# Altium schematic sheet 09_Misc.SchDoc(09_Misc)
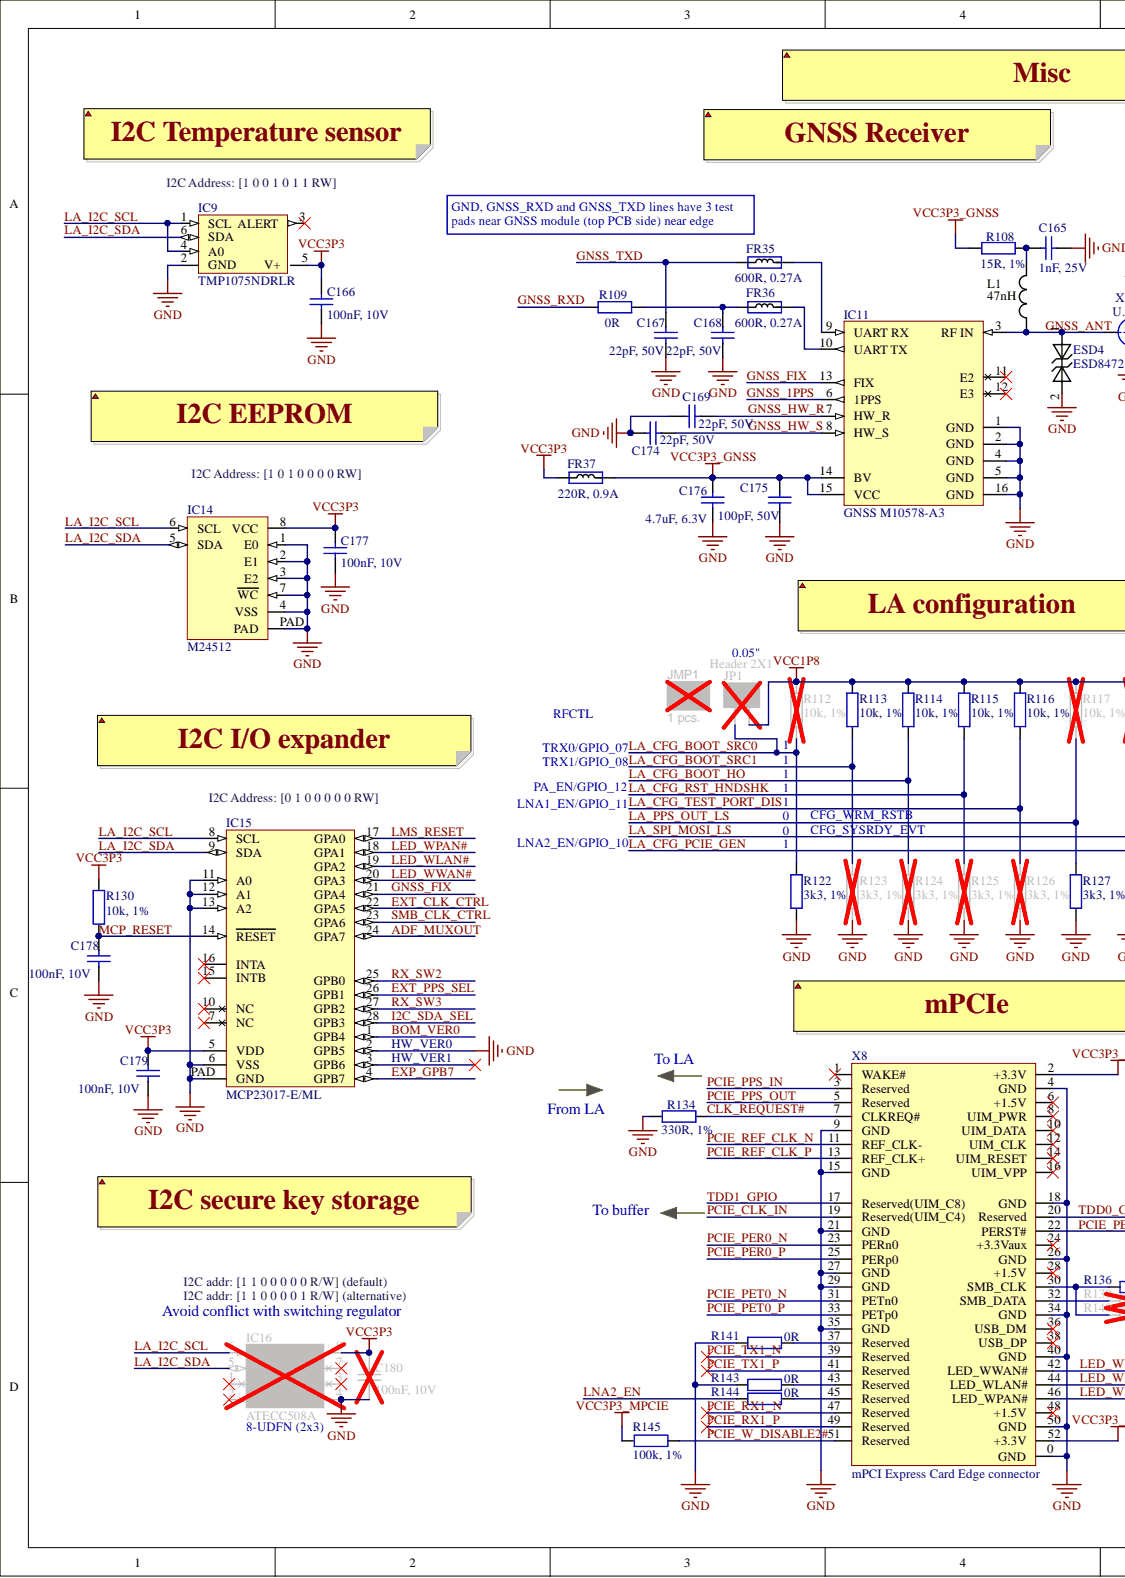
[diagram p0: 09_Misc.SchDoc, left]
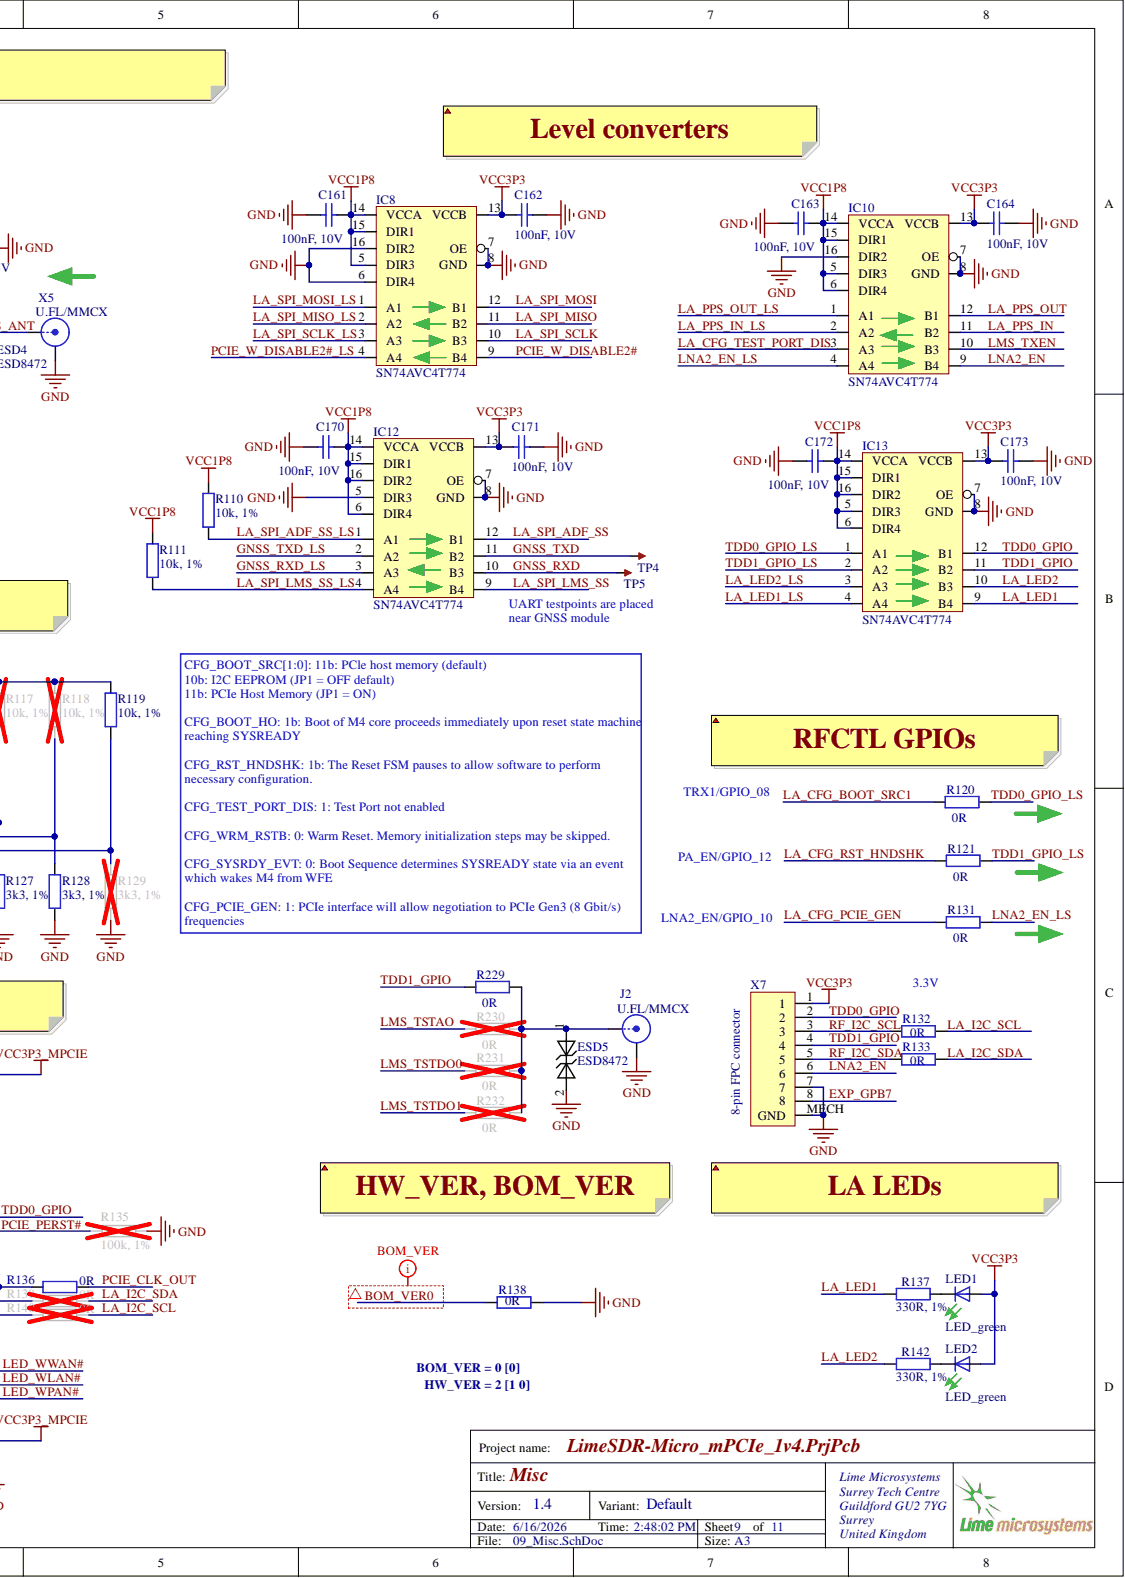
[diagram p1: 09_Misc.SchDoc, right]

## Parts
C161  100nF, 10V  Voltage Rating (DC): 10V  [CAP0201]
C162  100nF, 10V  Voltage Rating (DC): 10V  [CAP0201]
C163  100nF, 10V  Voltage Rating (DC): 10V  [CAP0201]
C164  100nF, 10V  Voltage Rating (DC): 10V  [CAP0201]
C165  1nF, 25V  Voltage - Rated: 25V  [CAP0201]
C166  100nF, 10V  Voltage Rating (DC): 10V  [CAP0201]
C167  22pF, 50V  Voltage Rating (DC): 50V  [CAP0201]
C168  22pF, 50V  Voltage Rating (DC): 50V  [CAP0201]
C169  22pF, 50V  Voltage Rating (DC): 50V  [CAP0201]
C170  100nF, 10V  Voltage Rating (DC): 10V  [CAP0201]
C171  100nF, 10V  Voltage Rating (DC): 10V  [CAP0201]
C172  100nF, 10V  Voltage Rating (DC): 10V  [CAP0201]
C173  100nF, 10V  Voltage Rating (DC): 10V  [CAP0201]
C174  22pF, 50V  [CAP0201]
C175  100pF, 50V  Voltage Rating (DC): 50V  [CAP0201]
C176  4.7uF, 6.3V  Voltage - Rated: 6.3V  [CAP0402]
C177  100nF, 10V  Voltage Rating (DC): 10V  [CAP0201]
C178  100nF, 10V  Voltage Rating (DC): 10V  [CAP0201]
C179  100nF, 10V  Voltage Rating (DC): 10V  [CAP0201]
C180  100nF, 10V  Voltage Rating (DC): 10V  [CAP0201]
ESD4  ESD8472  [ESD8472_X3DFN2]
ESD5  ESD8472  [ESD8472_X3DFN2]
FR35  600R, 0.27A  [RES0201]
FR36  600R, 0.27A  [RES0201]
FR37  220R, 0.9A  [RES0402]
IC8  SN74AVC4T774  [SN74AVC4T774_v1]
IC9  TMP1075NDRLR  [TMP1075NDRLR_temperature_sensor_SOT563]
IC10  SN74AVC4T774  [SN74AVC4T774_v1]
IC11  GNSS M10578-A3  Manufacturer Part Number 1: M10578-A3  Data Interface: UART  [M10578-A3_v2]
IC12  SN74AVC4T774  [SN74AVC4T774_v1]
IC13  SN74AVC4T774  [SN74AVC4T774_v1]
IC14  M24512  [M24128-DFMC6TG]
IC15  MCP23017-E/ML  [MCP23017-E/ML_QFN28]
IC16  ATECC508A  [ATECC508A_UDFN8_v2]
J2  U.FL/MMCX  [MMCX_UFL_conn_u_v2]
JMP1  1 pcs.  [MECH_JUMPER_HALF_PITCH]
JP1  Header 2X1  [HEADER_2x1_TH_HALF_PITCH]
L1  47nH  [RES0402]
LED1  LED_green  [LED0201]
LED2  LED_green  [LED0201]
R108  15R, 1%  [RES0201]
R109  0R  [RES0201]
R110  10k, 1%  Tolerance: 1%  [RES0201]
R111  10k, 1%  Tolerance: 1%  [RES0201]
R112  10k, 1%  [RES0201]
R113  10k, 1%  Tolerance: 1%  [RES0201]
R114  10k, 1%  Tolerance: 1%  [RES0201]
R115  10k, 1%  Tolerance: 1%  [RES0201]
R116  10k, 1%  Tolerance: 1%  [RES0201]
R117  10k, 1%  Tolerance: 1%  [RES0201]
R118  10k, 1%  Tolerance: 1%  [RES0201]
R119  10k, 1%  Tolerance: 1%  [RES0201]
R120  0R  [RES0201]
R121  0R  [RES0201]
R122  3k3, 1%  [RES0201]
R123  3k3, 1%  Tolerance: 1%  [RES0201]
R124  3k3, 1%  Tolerance: 1%  [RES0201]
R125  3k3, 1%  Tolerance: 1%  [RES0201]
R126  3k3, 1%  Tolerance: 1%  [RES0201]
R127  3k3, 1%  Tolerance: 1%  [RES0201]
R128  3k3, 1%  Tolerance: 1%  [RES0201]
R129  3k3, 1%  Tolerance: 1%  [RES0201]
R130  10k, 1%  Tolerance: 1%  [RES0201]
R131  0R  [RES0201]
R132  0R  [RES0201]
R133  0R  [RES0201]
R134  330R, 1%  Tolerance: 1%  [RES0201]
R135  100k, 1%  Tolerance: 1%  [RES0201]
R136  0R  [RES0201]
R137  330R, 1%  Tolerance: 1%  [RES0201]
R138  0R  [RES0201]
R139  0R  [RES0201]
R140  0R  [RES0201]
R141  0R  [RES0201]
R142  330R, 1%  Tolerance: 1%  [RES0201]
R143  0R  [RES0201]
R144  0R  [RES0201]
R145  100k, 1%  Tolerance: 1%  [RES0201]
R229  0R  [RES0201]
R230  0R  [RES0201]
R231  0R  [RES0201]
R232  0R  [RES0201]
X5  U.FL/MMCX  [MMCX_UFL_conn_u_v2]
X7  8-pin FPC connector  [503480-0800_8PIN_FPC_CONN]
X8  mPCI Express Card Edge connector  [PCIe_MiniCard]  (no BOM)

## Nets
GND: C161.1 C162.2 C163.1 C164.2 C165.2 C166.2 C167.2 C168.2 C169.2 C170.1 C171.2 C172.1 C173.2 C174.2 C175.1 C176.1 C177.2 C178.2 C179.2 C180.2 ESD4.2 ESD5.2 IC8.6(DIR4) IC8.7(OE) IC8.8(GND) IC8.16(DIR2) IC9.2(GND) IC10.7(OE) IC10.8(GND) IC10.16(DIR2) IC11.1(GND) IC11.2(GND) IC11.4(GND) IC11.5(GND) IC11.16(GND) IC12.5(DIR3) IC12.7(OE) IC12.8(GND) IC13.7(OE) IC13.8(GND) IC14.1(E0) IC14.2(E1) IC14.3(E2) IC14.4(VSS) IC14.7(WC) IC14.PAD IC15.2(GPB5) IC15.6(VSS) IC15.11(A0) IC15.12(A1) IC15.13(A2) IC15.PAD(GND) IC16.4(GND) J2.2 R122.2 R123.2 R124.2 R125.2 R126.2 R127.2 R128.2 R129.2 R134.2 R135.1 R138.2 R141.1 R143.1 X5.2 X7.7 X7.MECH(GND) X8.0(GND) X8.4(GND) X8.9(GND) X8.15(GND) X8.18(GND) X8.21(GND) X8.26(GND) X8.27(GND) X8.29(GND) X8.34(GND) X8.35(GND) X8.40(GND) X8.50(GND)
VCC1P8: C161.2 C163.2 C170.2 C172.2 IC8.5(DIR3) IC8.14(VCCA) IC8.15(DIR1) IC10.5(DIR3) IC10.6(DIR4) IC10.14(VCCA) IC10.15(DIR1) IC12.6(DIR4) IC12.14(VCCA) IC12.15(DIR1) IC12.16(DIR2) IC13.5(DIR3) IC13.6(DIR4) IC13.14(VCCA) IC13.15(DIR1) IC13.16(DIR2) JP1.2 R110.1 R111.1 R112.1 R113.1 R114.1 R115.1 R116.1 R117.1 R118.1 R119.1
VCC3P3: C162.1 C164.1 C166.1 C171.1 C173.1 C177.1 C179.1 C180.1 FR37.1 IC8.13(VCCB) IC9.5(V+) IC10.13(VCCB) IC12.13(VCCB) IC13.13(VCCB) IC14.8(VCC) IC15.5(VDD) IC16.8(VCC) LED1.A LED2.A R130.1 X7.1
VCC3P3_GNSS: C175.2 C176.2 FR37.2 IC11.14(BV) IC11.15(VCC) R108.2
VCC3P3_MPCIE: R145.2 X8.2(+3.3V) X8.52(+3.3V)
ADF_MUXOUT: IC15.24(GPA7)
BOM_VER0: IC15.1(GPB4) R138.1
CLK_REQUEST#: R134.1 X8.7(CLKREQ#)
EXP_GPB7: IC15.4(GPB7) X7.8
EXT_CLK_CTRL: IC15.22(GPA5)
EXT_PPS_SEL: IC15.26(GPB1)
GNSS_1PPS: IC11.6(1PPS)
GNSS_ANT: ESD4.1 IC11.3(RF_IN) L1.1 X5.1
GNSS_FIX: IC11.13(FIX) IC15.21(GPA4)
GNSS_HW_R: C169.1 IC11.7(HW_R)
GNSS_HW_S: C174.1 IC11.8(HW_S)
GNSS_RXD: IC12.10(B3) R109.1
GNSS_RXD_LS: IC12.3(A3)
GNSS_TXD: C167.1 FR35.1 IC12.11(B2)
GNSS_TXD_LS: IC12.2(A2)
I2C_SDA_SEL: IC15.28(GPB3)
LA_CFG_BOOT_HO: R114.2 R124.1
LA_CFG_BOOT_SRC0: JP1.1 R112.2 R122.1
LA_CFG_BOOT_SRC1: R113.2 R120.1 R123.1
LA_CFG_PCIE_GEN: R119.2 R129.1 R131.1
LA_CFG_RST_HNDSHK: R115.2 R121.1 R125.1
LA_CFG_TEST_PORT_DIS: IC10.3(A3) R116.2 R126.1
LA_I2C_SCL: IC9.1(SCL) IC9.4(A0) IC14.6(SCL) IC15.8(SCL) IC16.6(SCL) R132.2 R140.2
LA_I2C_SDA: IC9.6(SDA) IC14.5(SDA) IC15.9(SDA) IC16.5(SDA) R133.2 R139.2
LA_LED1: IC13.9(B4) R137.2
LA_LED1_LS: IC13.4(A4)
LA_LED2: IC13.10(B3) R142.2
LA_LED2_LS: IC13.3(A3)
LA_PPS_IN: IC10.11(B2)
LA_PPS_IN_LS: IC10.2(A2)
LA_PPS_OUT: IC10.12(B1)
LA_PPS_OUT_LS: IC10.1(A1) R117.2 R127.1
LA_SPI_ADF_SS: IC12.12(B1)
LA_SPI_ADF_SS_LS: IC12.1(A1) R110.2
LA_SPI_LMS_SS: IC12.9(B4)
LA_SPI_LMS_SS_LS: IC12.4(A4) R111.2
LA_SPI_MISO: IC8.11(B2)
LA_SPI_MISO_LS: IC8.2(A2)
LA_SPI_MOSI: IC8.12(B1)
LA_SPI_MOSI_LS: IC8.1(A1) R118.2 R128.1
LA_SPI_SCLK: IC8.10(B3)
LA_SPI_SCLK_LS: IC8.3(A3)
LED_WLAN#: IC15.19(GPA2) X8.44(LED_WLAN#)
LED_WPAN#: IC15.18(GPA1) X8.46(LED_WPAN#)
LED_WWAN#: IC15.20(GPA3) X8.42(LED_WWAN#)
LMS_RESET: IC15.17(GPA0)
LMS_TSTAO: R230.1
LMS_TSTDO0: R231.1
LMS_TSTDO1: R232.1
LMS_TXEN: IC10.10(B3)
LNA2_EN: IC10.9(B4) R144.1 X7.6
LNA2_EN_LS: IC10.4(A4) R131.2
MCP_RESET: C178.1 IC15.14(RESET) R130.2
PCIE_CLK_IN: X8.19(Reserved(UIM_C4))
PCIE_CLK_OUT: R136.2
PCIE_PER0_N: X8.23(PERn0)
PCIE_PER0_P: X8.25(PERp0)
PCIE_PERST#: R135.2 X8.22(PERST#)
PCIE_PET0_N: X8.31(PETn0)
PCIE_PET0_P: X8.33(PETp0)
PCIE_PPS_IN: X8.3(Reserved)
PCIE_PPS_OUT: X8.5(Reserved)
PCIE_REF_CLK_N: X8.11(REF_CLK-)
PCIE_REF_CLK_P: X8.13(REF_CLK+)
PCIE_RX1_N: X8.47(Reserved)
PCIE_RX1_P: X8.49(Reserved)
PCIE_TX1_N: X8.39(Reserved)
PCIE_TX1_P: X8.41(Reserved)
PCIE_W_DISABLE2#: IC8.9(B4) R145.1 X8.51(Reserved)
PCIE_W_DISABLE2#_LS: IC8.4(A4)
RF_I2C_SCL: R132.1 X7.3
RF_I2C_SDA: R133.1 X7.5
RX_SW2: IC15.25(GPB0)
RX_SW3: IC15.27(GPB2)
SMB_CLK_CTRL: IC15.23(GPA6)
TDD0_GPIO: IC13.12(B1) X7.2 X8.20(Reserved)
TDD0_GPIO_LS: IC13.1(A1) R120.2
TDD1_GPIO: IC13.11(B2) R229.1 X7.4 X8.17(Reserved(UIM_C8))
TDD1_GPIO_LS: IC13.2(A2) R121.2
NetC165_1: C165.1 L1.2 R108.1
NetC168_1: C168.1 FR36.1 R109.2
NetESD5_1: ESD5.1 J2.1 R229.2 R230.2 R231.2 R232.2
NetFR35_2: FR35.2 IC11.9(UART_RX)
NetFR36_2: FR36.2 IC11.10(UART_TX)
NetLED1_K: LED1.K R137.1
NetLED2_K: LED2.K R142.1
NetR136_1: R136.1 R140.1 X8.30(SMB_CLK)
NetR139_1: R139.1 X8.32(SMB_DATA)
NetR141_2: R141.2 X8.37(Reserved)
NetR143_2: R143.2 X8.43(Reserved)
NetR144_2: R144.2 X8.45(Reserved)
N00444:

Not connected: IC9 3(ALERT); IC11 11(E2) 12(E3); IC15 3(GPB6) 7(NC) 10(NC) 15(INTB) 16(INTA); IC16 1(NC) 2(NC) 3(NC) 7(NC); X8 1(WAKE#) 6(+1.5V) 8(UIM_PWR) 10(UIM_DATA) 12(UIM_CLK) 14(UIM_RESET) 16(UIM_VPP) 24(+3.3Vaux) 28(+1.5V) 36(USB_DM) 38(USB_DP) 48(+1.5V)

## Library data of the parts
C161-C164 C166 C170-C173 C177-C180 = Cap_0402: Part Description: 0.1 µF ±20% 10V Ceramic Capacitor X5R 0201 (0603 Metric); Manufacturer Part Number 1: CL03A104MP3NNNC; Manufacturer: Samsung; Tolerance: 20%; Width: 0.012inch; Voltage Rating: 10V; Value: 100nF, 10V; Thickness: 0.013inch; Supplier Part Number 1: 1276-1321-1-ND; Supplier 1: Digi-Key; Stock 1: 3817176; Series: CL; RoHS Compliant: Yes; RoHS: Yes; Pricing 1: 1=0,10000, 10=0,03000, 50=0,01640, 100=0,01330, 500=0,00950, 1000=0,00747, 2500=0,00679, 5000=0,00625 (USD); Packaging: Tape and Reel; Package Quantity: 1; Mount: Surface Mount; Min Operating Temperature: -55°C; Max Operating Temperature: 85°C; Length: 0.024inch; ComponentLink5URL: https://datasheet.ciiva.com/25788/cl03a104mp3nnnc-25788272.pdf?src-supplier=Digi-Key; ComponentLink5Description: https://datasheet.ciiva.com/25788/cl03a104mp3nnnc-25788272.pdf?src-supplier=Digi-Key; Component Kind: Standard; Case Code (Metric): 0603; Case Code (Imperial): 0201; Case/Package: 0201; Capacitance: 100nF; Library Name: LimeMicroAltiumLib_schLib.SchLib
C165 = Cap_0402: Part Description: 1000pF ±10% 25V X7R Ceramic Capacitor -55°C ~ 125°C Surface Mount, MLCC 0201 (0603 Metric) 0.024" L x 0.012" W (0.60mm x 0.30mm); Manufacturer Part Number 1: C0603X7R1E102K030BA; Manufacturer: TDK Corporation; Tolerance: ±10%; Temperature Coefficient: X7R; Capacitance: 1000pF; Value: 1nF, 25V; Thickness (Max): 0.013" (0.33mm); Supplier Part Number 1: 445-7275-1-ND; Supplier 1: Digi-Key; Size / Dimension: 0.024" L x 0.012" W (0.60mm x 0.30mm); RoHS: RoHS Compliant; Ratings: -; Packaging: Cut Tape (CT); Package / Case: 0201 (0603 Metric); Operating Temperature: -55°C ~ 125°C; Mounting Type: Surface Mount, MLCC; Lead Style: -; Lead Spacing: -; Height - Seated (Max): -; Features: -; Component Kind: Standard; Category: Capacitors; Applications: General Purpose; Library Name: LimeMicroAltiumLib_schLib.SchLib
C167-C169 C174 = Cap_0402: Part Description: 22 pF ±5% 50V Ceramic Capacitor C0G, NP0 0201 (0603 Metric); Manufacturer Part Number 1: GRM0335C1H220JA01D; Manufacturer: Murata; Tolerance: 5%; Dielectric: C0G; Width: 0.012inch; Voltage Rating: 50V; Voltage: 50V; Value: 22pF, 50V; Thickness: 0.013inch; Termination: SMD/SMT; Supplier Part Number 1: 490-6123-1-ND; Supplier 1: Digi-Key; Stock 1: 2966748; Series: GRM; RoHS Compliant: Yes; RoHS: Yes; Pricing 1: 1=0,10000, 10=0,01300, 50=0,00720, 100=0,00590, 500=0,00424, 1000=0,00333, 2500=0,00303, 5000=0,00279 (USD); Packaging: Tape and Reel; Package Quantity: 15000; Mount: Surface Mount; Min Operating Temperature: -55°C; Max Operating Temperature: 125°C; Length: 0.6mm; Lead Free: Lead Free; Height: 0.3mm; Depth: 0.3mm; ComponentLink3URL: https://datasheet.ciiva.com/6271/partnumbering-e-01-6271149.pdf?src-supplier=Digi-Key; ComponentLink3Description: https://datasheet.ciiva.com/6271/partnumbering-e-01-6271149.pdf?src-supplier=Digi-Key; ComponentLink2URL: https://datasheet.ciiva.com/6271/partnumbering-e-01-6271143.pdf?src-supplier=Digi-Key; ComponentLink2Description: https://datasheet.ciiva.com/6271/partnumbering-e-01-6271143.pdf?src-supplier=Digi-Key; ComponentLink1URL: https://datasheet.ciiva.com/6271/partnumbering-e-01-6271146.pdf?src-supplier=Digi-Key; ComponentLink1Description: https://datasheet.ciiva.com/6271/partnumbering-e-01-6271146.pdf?src-supplier=Digi-Key; Component Kind: Standard; Case Code (Metric): 0603; Case Code (Imperial): 0201; Case/Package: 0201; Capacitance: 22pF; Library Name: LimeMicroAltiumLib_schLib.SchLib
  C174: Voltage Rating (DC): 50V
C175 = Cap_0402: Part Description: 100 pF ±5% 50V Ceramic Capacitor C0G, NP0 0201 (0603 Metric); Manufacturer Part Number 1: C0603C0G1H101J030BA; Manufacturer: TDK; Tolerance: 5%; Insulation Resistance: 10GR; Dielectric Material: Ceramic; Dielectric: C0G; Width: 0.012inch; Weight: 6E-06oz; Voltage Rating: 50V; Voltage: 50V; Value: 100pF, 50V; Thickness: 0.013inch; Termination: SMD/SMT; Supplier Part Number 1: 445-1786-1-ND; Supplier 1: Digi-Key; Stock 1: 367528; Series: C; RoHS Compliant: Yes; RoHS: Yes; Reach SVHC Compliant: Yes; Pricing 1: 1=0,10000, 10=0,06000, 50=0,03280, 100=0,02670, 500=0,01908, 1000=0,01500, 2500=0,01364, 5000=0,01254 (USD); Packaging: Tape and Reel; Package Quantity: 1; Number of Pins: 2; Mount: Surface Mount; Min Operating Temperature: -55°C; Max Operating Temperature: 125°C; Length: 0.6mm; Lead Free: Lead Free; Height: 0.3mm; Depth: 0.3mm; Construction: Flat; ComponentLink3URL: https://datasheet.ciiva.com/6250/tdkmlcccaprange-6250351.pdf?src-supplier=Digi-Key; ComponentLink3Description: https://datasheet.ciiva.com/6250/tdkmlcccaprange-6250351.pdf?src-supplier=Digi-Key; ComponentLink2URL: https://datasheet.ciiva.com/16205/mlcc-commercial-general-en-16205590.pdf?src-supplier=Future+Electronics; ComponentLink2Description: https://datasheet.ciiva.com/16205/mlcc-commercial-general-en-16205590.pdf?src-supplier=Future+Electronics; ComponentLink1URL: https://datasheet.ciiva.com/24217/c0603c0g1h101j030ba-264106-24217425.pdf?src-supplier=Mouser; ComponentLink1Description: https://datasheet.ciiva.com/24217/c0603c0g1h101j030ba-264106-24217425.pdf?src-supplier=Mouser; Component Kind: Standard; Case Code (Metric): 0603; Case Code (Imperial): 0201; Case/Package: 0201; Capacitance: 100pF; Library Name: LimeMicroAltiumLib_schLib.SchLib
C176 = Cap_0402: Part Description: 4.7µF ±20% 6.3V X5R Ceramic Capacitor -55°C ~ 85°C Surface Mount, MLCC 0402 (1005 Metric) 0.039" L x 0.020" W (1.00mm x 0.50mm); Manufacturer Part Number 1: GRM155R60J475ME87D; Manufacturer: Murata Electronics North America; Tolerance: ±20%; Temperature Coefficient: X5R; Capacitance: 4.7µF; Value: 4.7uF, 6.3V; Thickness (Max): 0.022" (0.55mm); Supplier Part Number 1: 490-5408-1-ND; Supplier 1: Digi-Key; Size / Dimension: 0.039" L x 0.020" W (1.00mm x 0.50mm); RoHS: RoHS Compliant; Ratings: -; Packaging: Cut Tape (CT); Package / Case: 0402 (1005 Metric); Operating Temperature: -55°C ~ 85°C; Mounting Type: Surface Mount, MLCC; Lead Style: -; Lead Spacing: -; Height - Seated (Max): -; Features: Derating Recommended; Component Kind: Standard; Category: Capacitors; Applications: General Purpose; Library Name: LimeMicroAltiumLib_schLib.SchLib
ESD4 ESD5 = ESD8472: Part Description: TVS DIODE 5.3VWM 14VC X3DFN2; Manufacturer Part Number 1: ESD8472MUT5G; Manufacturer: ON Semiconductor; Voltage - Reverse Standoff (Typ): 5.3V (Max); Voltage - Clamping (Max) @ Ipp: 14V; Voltage - Breakdown (Min): 7V; Power Line Protection: No; Current - Peak Pulse (10/1000µs): 3A (8/20µs); Capacitance @ Frequency: 0.2pF @ 1MHz; Type: Zener; Supplier Part Number 1: ESD8472MUT5GOSCT-ND; Supplier Device Package: 2-X3DFN (0.62x0.32); Supplier 1: Digi-Key; Stock 1: 2295; RoHS: RoHS Compliant; Pricing 1: 1=0.39, 10=0.315, 100=0.2142, 500=0.16068, 1000=0.12051 (USD); Power - Peak Pulse: -; Part Status: Active; Packaging: Cut Tape (CT); Package / Case: 2-XFDFN; Operating Temperature: -55°C ~ 150°C (TJ); Mounting Type: Surface Mount; ComponentLink1URL: http://www.onsemi.com/pub/Collateral/ESD8472-D.PDF; ComponentLink1Description: http://www.onsemi.com/pub/Collateral/ESD8472-D.PDF; Component Kind: Standard; Category: Circuit Protection; Applications: Automotive, RF Antenna; Library Name: LimeMicroAltiumLib_schLib.SchLib
FR35 FR36 = Ferrite: Part Description: FERRITE BEAD 600 OHM 0201 1LN; Manufacturer Part Number 1: BK0603TS601-T; Manufacturer: Taiyo Yuden; Value: 600R@100MHz; Impedance @ Frequency: 600 Ohm @ 100MHz; Filter Type: Differential Mode - Single; DC Resistance (DCR): 750 mOhm Max; Current Rating: 270mA; Supplier Part Number 1: 587-3277-1-ND; Supplier 1: Digi-Key; Size / Dimension: 0.024" L x 0.012" W (0.60mm x 0.30mm); RoHS: RoHS Compliant; Packaging: Cut Tape (CT); Package / Case: 0201 (0603 Metric); Mounting Type: Surface Mount; Height (Max): 0.013" (0.33mm); Component Kind: Standard; Category: Filters; Library Name: LimeMicroAltiumLib_schLib.SchLib
FR37 = Ferrite: Part Description: FERRITE BEAD 220 OHM 0402 1LN; Manufacturer Part Number 1: MPZ1005S221ET000; Manufacturer: TDK Corporation; Impedance @ Frequency: 220 Ohm @ 100MHz; DC Resistance (DCR): 220 mOhm Max; Current Rating: 900mA; Value: 220R, 0.9A; Supplier Part Number 1: 445-9329-2-ND; Supplier 1: Digi-Key; Package / Case: 0402 (1005 Metric); Component Kind: Standard; Category: Filters; Library Name: LimeFPGAboard_schLib.SchLib
IC8 IC10 IC12 IC13 = SN74AVC4T774: Part Description: Voltage Level Translator Bidirectional 1 Circuit 4 Channel 380Mbps 16-UQFN (2.6x1.8); Manufacturer Part Number 1: SN74AVC4T774RSVR; Manufacturer: Texas Instruments; Voltage - Supply: 1.2 V ~ 3.6 V; Propagation Delay (Max): 2.4ns; Output Type: Logic; Number of Outputs/Channel: 1; Number of Channels: 4; Logic Function: Translator, Bidirectional, 3-State; Differential - Input: No/No; Data Rate: 380Mbps; Supplier Part Number 1: 296-24741-6-ND; Supplier Device Package: 16-UQFN (2.6x1.8); Supplier 1: Digi-Key; RoHS: RoHS Compliant; Packaging: Digi-Reel®; Package / Case: 16-UFQFN; Operating Temperature: -40°C ~ 85°C; Number of Bits: 4; Mounting Type: Surface Mount; Input Type: Logic; Component Kind: Standard; Category: Integrated Circuits (ICs); Library Name: LimeMicroAltiumLib.SchLib
IC9 = TMP1075NDRLR_temperature_sensor: Part Description: Temperature Sensor Digital, Local -40°C ~ 125°C 12 b SOT-563; Manufacturer: Texas Instruments; Supplier Part Number 1: 296-TMP1075NDRLRCT-ND; Supplier 1: Digi-Key; Stock 1: 3405; RoHS Compliant: Yes; RoHS: No; Pricing 1: 1=0,67000, 5=0,63800, 10=0,55500, 25=0,48760, 50=0,40500, 100=0,34500, 500=0,30000, 1000=0,26250 (USD); Manufacturer Part Number 1: TMP1075NDRLR; Component Kind: Standard; Library Name: LimeMicroAltiumLib_schLib_r04.SchLib
IC11 = M10578-A3_GPS_GNSS: Part Description: RADIONOVA RF Receiver GPS 1.575GHz -165dBm Module; Manufacturer: Antenova; Voltage - Supply: 3.3V; Sensitivity: -165dBm; Modulation or Protocol: GPS; Frequency: 1.575GHz; Current - Receiving: 28mA; Supplier Part Number 1: 627-1074-1-ND; Supplier Device Package: Module; Supplier 1: Digi-Key; Stock 1: 0; RoHS: RoHS Compliant; Pricing 1: 1=17.75, 10=16.061, 25=14.7928, 100=13.5248, 250=12.67952, 500=11.8342 (USD); Part Status: Active; Packaging: Cut Tape (CT); Package / Case: Module; Operating Temperature: -40°C ~ 85°C; Memory Size: -; Features: -; Data Rate (Max): -; ComponentLink1URL: http://www.antenova-m2m.com/wp-content/uploads/2015/12/GPS_Radionova_M10578-A3-PS-1.0.pdf; ComponentLink1Description: http://www.antenova-m2m.com/wp-content/uploads/2015/12/GPS_Radionova_M10578-A3-PS-1.0.pdf; Component Kind: Standard; Category: RF/IF and RFID; Applications: General Purpose; Antenna Connector: -; Library Name: LimeMicroAltiumLib_schLib_r04.SchLib
IC14 = M24128-DFMC6TG: Part Description: IC EEPROM 512KBIT I2C 8UFDFPN; Manufacturer Part Number 1: M24512-DFMC6TG; Manufacturer: STMicroelectronics; Supplier Part Number 1: 497-15745-1-ND; Supplier 1: Digi-Key; Stock 1: 12789; RoHS: Yes; Pricing 1: 1=1,03, 10=0,935, 25=0,9232, 50=0,9178, 100=0,8206, 250=0,81368, 500=0,80166, 1000=0,77298 (USD); ComponentLink2URL: https://datasheet.ciiva.com/29611/cd00251873-29611552.pdf?src-supplier=Digi-Key; ComponentLink2Description: https://datasheet.ciiva.com/29611/cd00251873-29611552.pdf?src-supplier=Digi-Key; ComponentLink1URL: http://www.st.com/content/ccc/resource/technical/document/datasheet/59/05/c9/5b/7b/41/48/b6/CD00259167.pdf/files/CD00259167.pdf/jcr:content/translations/en.CD00259167.pdf; ComponentLink1Description: http://www.st.com/content/ccc/resource/technical/document/datasheet/59/05/c9/5b/7b/41/48/b6/CD00259167.pdf/files/CD00259167.pdf/jcr:content/translations/en.CD00259167.pdf; Component Kind: Standard; Library Name: LimeMicroAltiumLib_schLib.SchLib
IC15 = MCP23017-E/ML_I2C_expander: Part Description: I/O Expander 16 I²C 1.7 MHz 28-QFN (6x6); Manufacturer: Microchip; Supplier Part Number 1: MCP23017-E/ML-ND; Supplier 1: Digi-Key; Stock 1: 3965; RoHS: Yes; Pricing 1: 1=1,9, 25=1,59, 100=1,44 (USD); Manufacturer Part Number 1: MCP23017-E/ML; ComponentLink4URL: https://datasheet.ciiva.com/16222/filehandler-aspxddocname-en023709-16222331.pdf?src-supplier=Future+Electronics; ComponentLink4Description: https://datasheet.ciiva.com/16222/filehandler-aspxddocname-en023709-16222331.pdf?src-supplier=Future+Electronics; ComponentLink3URL: https://datasheet.ciiva.com/3149/21952b-3149158.pdf?src-supplier=Avnet; ComponentLink3Description: https://datasheet.ciiva.com/3149/21952b-3149158.pdf?src-supplier=Avnet; ComponentLink2URL: https://datasheet.ciiva.com/1780/21952b-1780121.pdf?src-supplier=Digi-Key; ComponentLink2Description: https://datasheet.ciiva.com/1780/21952b-1780121.pdf?src-supplier=Digi-Key; ComponentLink1URL: https://datasheet.ciiva.com/1496/12179-1496011.pdf?src-supplier=Element14; ComponentLink1Description: https://datasheet.ciiva.com/1496/12179-1496011.pdf?src-supplier=Element14; Component Kind: Standard; Library Name: LimeMicroAltiumLib.SchLib
IC16 = ATECC508A_authentication_IC: Part Description: Authentication Chip IC Networking and Communications 8-UDFN (2x3); Manufacturer Part Number 1: ATECC508A-MAHDA-S; Manufacturer: Microchip; Supplier Part Number 1: ATECC508A-MAHDA-SDKR-ND; Supplier 1: Digi-Key; Stock 1: 27941; Pricing 1: 1=0.73, 25=0.7064 (USD); Component Kind: Standard; Library Name: LimeMicroAltiumLib_schLib_r01.SchLib
J2 X5 = RF_IF_connector: CONN UMC RCPT STR 50 OHM SMD; Part Description: Ultra Miniature Coaxial Connector Receptacle, Male Pin 50 Ohm Surface Mount Solder; Manufacturer Part Number 1: 0734120110; Manufacturer: Molex, LLC; Voltage Rating: 60V; Shield Termination: Solder; Impedance: 50 Ohm; Frequency - Max: 6GHz; Dielectric Material: Liquid Crystal Polymer (LCP); Connector Type: Jack, Male Pin; Connector Style: Ultra Miniature Coaxial; Center Contact Plating: Gold; Center Contact Material: Brass; Body Material: Phosphor Bronze; Body Finish: Nickel; Supplier Part Number 1: WM5587CT-ND; Supplier 1: Digi-Key; RoHS: RoHS Compliant; Packaging: Cut Tape (CT); Operating Temperature: -40°C ~ 90°C; Mounting Type: Surface Mount; Ingress Protection: -; Housing Color: Silver; Features: -; Fastening Type: Snap-On; Contact Termination: Solder; Component Kind: Standard; Category: Connectors, Interconnects; Cable Group: -; Library Name: LimeMicroAltiumLib_schLib.SchLib
JMP1 = JUMP_2P_CONN_HEADER: Shorting Jumper, 2-position, 1.27mm pitch; Manufacturer Part Number 1: NPB02SVAN-RC; Manufacturer: Sullins; Number of Positions: 2; Supplier Part Number 1: S9345-ND; Supplier 1: DigiKey; Stock 1: 69438; RoHS: Compliant; REACH SVHC: Yes; Pricing 1: 1=0.17, 10=0.147, 25=0.1376, 50=0.1308, 100=0.1246, 250=0.11676, 500=0.11116, 1000=0.10585, 3000=0.09795 (USD); Pitch: 1.27mm; Packaging: Bulk; Lifecycle Status: Production; Lead Free: Lead Free; Height: 2.9972mm; Contact Plating: Gold; ComponentLink3URL: https://datasheet.octopart.com:443/NPB02SVAN-RC-Sullins-datasheet-12512856.pdf?src-supplier=Future+Electronics; ComponentLink3Description: https://datasheet.octopart.com:443/NPB02SVAN-RC-Sullins-datasheet-12512856.pdf?src-supplier=Future+Electronics; ComponentLink2URL: https://datasheet.octopart.com:443/NPB02SVAN-RC-Sullins-datasheet-41399304.pdf?src-supplier=Future+Electronics; ComponentLink2Description: https://datasheet.octopart.com:443/NPB02SVAN-RC-Sullins-datasheet-41399304.pdf?src-supplier=Future+Electronics; ComponentLink1URL: https://datasheet.octopart.com:443/NPB02SVAN-RC-Sullins-datasheet-181250459.pdf?src-supplier=IHS; ComponentLink1Description: https://datasheet.octopart.com:443/NPB02SVAN-RC-Sullins-datasheet-181250459.pdf?src-supplier=IHS; Component Kind: Standard; Library Name: LimeMicroAltiumLib_schLib.SchLib
JP1 = Header_2X1: Manufacturer Part Number 1: M50-3530242; Manufacturer: Harwin; Number of Contacts: 2; Current Rating: 1A; Terminal Pitch: 1.27mm; Supplier Part Number 1: 952-3598-ND; Supplier 1: DigiKey; Stock 1: 34961; RoHS: Compliant; REACH SVHC: Yes; Pricing 1: 1=0.1, 10=0.084, 100=0.0713, 500=0.06358, 1000=0.06054, 5000=0.05403, 10000=0.05145 (USD); Number of Rows: 1; Number of Pins: 2; Min Operating Temperature: -40°C; Max Operating Temperature: 105°C; Lifecycle Status: Production; Introduction Date: 2016-09-30; Height: 4.3mm; Harmonized Tariff Schedule (HTS) Code: 8536.69.40.40; Export Control Classification Number (ECCN) Code: EAR99; Country of Origin: Taiwan; Contact Material: Brass; Contact Gender: Male; ComponentLink1URL: https://datasheet.octopart.com:443/M50-3530242-Harwin-datasheet-179992461.pdf?src-supplier=IHS; ComponentLink1Description: https://datasheet.octopart.com:443/M50-3530242-Harwin-datasheet-179992461.pdf?src-supplier=IHS; Component Kind: Standard; Library Name: LimeMicroAltiumLib.SchLib
L1 = Inductor_0603: Part Description: INDUCTOR, RF, 47NH, 5%, 2.4GHZ, SMD; Product Range:0402HP Series; Inductance:47nH; Inductance Tolerance:± 5%; High Frequency Inductor Case:0402 [1005 Metric]; Self Resonant Frequency Min:2.4GHz; DC Resistance Max:0.58ohm; DC Current Rating:420mA; Manufacturer Part Number 1: 0402HP-47NXJLU; Manufacturer: COILCRAFT; SVHC: No SVHC (15-Jun-2015); Self Resonant Frequency Min: 2.4; Product Range: 0402; Inductor Construction: Wirewound; Inductor Case Style: 0402 [1005 Metric]; Inductance Tolerance: ± 5%; Inductance: 47; DC Resistance Max: 0.58; DC Current Rating: 420; value: 47nH; Supplier Part Number 1: 2285856; Supplier 1: Farnell; RoHS: Y-EX; Packaging: Cut Tape; Manufacturer Part Number: 0402HP-47NXJLU; High Frequency Inductor Case: 0402 [1005 Metric]; Component Kind: Standard; Library Name: LimeMicroAltiumLib_schLib.SchLib
LED1 LED2 = LED_green: Part Description: Green 571nm LED Indication - Discrete 1.97V 2-SMD, 0201; Manufacturer Part Number 1: APG0603CGC-TT; Manufacturer: Kingbright; Viewing Angle: 140°; Test Current: 10mA; Luminous Intensity: 15mcd; Lens Transparency: Clear; Lens Color: Colorless; Forward Voltage: 1.97V; Dominant Wavelength: 571nm; Color: Green; Width: 0.35mm; Supplier Part Number 1: 754-2021-1-ND; Supplier 1: Digi-Key; Stock 1: 18826; RoHS Compliant: Yes; RoHS: Yes; Pricing 1: 1=0,50000, 10=0,30500, 100=0,17530, 1000=0,13720, 2000=0,12577 (USD); Packaging: Tape and Reel; Mount: Surface Mount; Length: 0.65mm; Lead Free: Lead Free; Height: 0.25mm; ComponentLink1URL: http://www.kingbrightusa.com/images/catalog/SPEC/APG0603CGC-TT.pdf?src-supplier=Digi-Key; ComponentLink1Description: http://www.kingbrightusa.com/images/catalog/SPEC/APG0603CGC-TT.pdf?src-supplier=Digi-Key; Component Kind: Standard; Case/Package: SMD/SMT; Library Name: LimeFPGAboard_schLib.SchLib
R108 = Res_0402: Part Description: 15 Ohms ±1% 0.05W, 1/20W Chip Resistor 0201 (0603 Metric) Moisture Resistant Thick Film; Manufacturer Part Number 1: RC0201FR-0715RL; Manufacturer: Yageo; Voltage Rating: 25V; Temperature Coefficient: 200ppm/°C; Power Rating: 50mW; Width: 0.3mm; Value: 15R, 1%; Tolerance: 1%; Termination: SMD/SMT; Supplier Part Number 1: 311-15.0MCT-ND; Supplier 1: Digi-Key; Stock 1: 379739; Size Code: 0201; Series: RC; RoHS Compliant: Yes; RoHS: Yes; Resistor Type: Thick Film; Resistance Tolerance: 1%; Resistance: 15R; Pricing 1: 1=0,10000, 10=0,03700, 100=0,01530, 1000=0,00685, 2500=0,00595, 5000=0,00491 (USD); Packaging: Cut Tape; Package Quantity: 1; Number of Terminations: 2; Min Operating Temperature: -55°C; Max Power Dissipation: 50mW; Max Operating Temperature: 125°C; Length: 0.6mm; Lead Free: Lead Free; Height: 0.23mm; Features: Moisture Resistant; ComponentLink6URL: https://datasheet.ciiva.com/2493/pyu-r-int-thick-7-2493784.pdf?src-supplier=Digi-Key; ComponentLink6Description: https://datasheet.ciiva.com/2493/pyu-r-int-thick-7-2493784.pdf?src-supplier=Digi-Key; ComponentLink5URL: https://datasheet.ciiva.com/2493/pyu-r-int-thick-7-2493786.pdf?src-supplier=Digi-Key; ComponentLink5Description: https://datasheet.ciiva.com/2493/pyu-r-int-thick-7-2493786.pdf?src-supplier=Digi-Key; ComponentLink4URL: https://datasheet.ciiva.com/2493/pyu-r-int-thick-7-2493785.pdf?src-supplier=Digi-Key; ComponentLink4Description: https://datasheet.ciiva.com/2493/pyu-r-int-thick-7-2493785.pdf?src-supplier=Digi-Key; ComponentLink3URL: https://datasheet.ciiva.com/7672/1563626-7672202.pdf?src-supplier=Element14; ComponentLink3Description: https://datasheet.ciiva.com/7672/1563626-7672202.pdf?src-supplier=Element14; ComponentLink2URL: https://datasheet.ciiva.com/22661/1563626-22661319.pdf?src-supplier=Element14; ComponentLink2Description: https://datasheet.ciiva.com/22661/1563626-22661319.pdf?src-supplier=Element14; ComponentLink1URL: https://datasheet.ciiva.com/5924/1563626-5924912.pdf?src-supplier=Farnell; ComponentLink1Description: https://datasheet.ciiva.com/5924/1563626-5924912.pdf?src-supplier=Farnell; Component Kind: Standard; Case Code (Metric): 0603; Case Code (Imperial): 0201; Case/Package: 0201; Library Name: LimeFPGAboard_schLib.SchLib
R109 R120 R121 R131-R133 R136 R138-R141 R143 R144 R229-R232 = Res_0402: Part Description: 0 Ohms Jumper Chip Resistor 0201 (0603 Metric) Moisture Resistant Thick Film; Manufacturer Part Number 1: RC0201JR-070RL; Manufacturer: Yageo; Voltage Rating (DC): 25V; Tolerance: 5%; Power Rating: 50mW; Width: 0.012inch; Weight: 6E-06oz; Voltage Rating: 25V; Value: 0R; Termination: SMD/SMT; Supplier Part Number 1: 311-0.0NCT-ND; Supplier 1: Digi-Key; Stock 1: 6252381; Size Code: 0201; Series: RC; RoHS Compliant: Yes; RoHS: Yes; Resistor Type: Thick Film; Resistance Tolerance: 5%; Resistance: 0R; Radiation Hardening: No; Pricing 1: 1=0,10000, 10=0,03700, 25=0,02680, 50=0,02020, 100=0,01500, 250=0,01140, 500=0,00912, 1000=0,00672, 5000=0,00482 (USD); Packaging: Tape and Reel; Package Quantity: 1; Number of Terminations: 2; Min Operating Temperature: -55°C; Military Standard: Not; Max Power Dissipation: 50mW; Max Operating Temperature: 125°C; Length: 0.024inch; Lead Free: Lead Free; Height: 0.01inch; Features: Moisture Resistant; Depth: 0.3mm; Contact Plating: Tin,Matte; Construction: Rectangular; ComponentLink5URL: https://datasheet.ciiva.com/46391/1421516-46391340.pdf?src-supplier=Element14; ComponentLink5Description: https://datasheet.ciiva.com/46391/1421516-46391340.pdf?src-supplier=Element14; ComponentLink4URL: https://datasheet.ciiva.com/7660/1421516-7660808.pdf?src-supplier=Element14; ComponentLink4Description: https://datasheet.ciiva.com/7660/1421516-7660808.pdf?src-supplier=Element14; ComponentLink3URL: https://datasheet.ciiva.com/7672/1563626-7672247.pdf?src-supplier=Element14; ComponentLink3Description: https://datasheet.ciiva.com/7672/1563626-7672247.pdf?src-supplier=Element14; ComponentLink2URL: https://datasheet.ciiva.com/7672/1563626-7672248.pdf?src-supplier=Element14; ComponentLink2Description: https://datasheet.ciiva.com/7672/1563626-7672248.pdf?src-supplier=Element14; ComponentLink1URL: http://www.yageo.com/documents/recent/PYu-RC_Group_51_RoHS_L_7.pdf; ComponentLink1Description: http://www.yageo.com/documents/recent/PYu-RC_Group_51_RoHS_L_7.pdf; Component Kind: Standard; Case Code (Metric): 0603; Case Code (Imperial): 0201; Case/Package: 0201; Library Name: LimeFPGAboard_schLib.SchLib
R110-R119 R130 = Res_0402: Part Description: 10 kOhms ±1% 0.05W, 1/20W Chip Resistor 0201 (0603 Metric) Moisture Resistant Thick Film; Manufacturer Part Number 1: RC0201FR-0710KL; Manufacturer: Yageo; Working Voltage: 25V; Temperature Coefficient: 200ppm/°C; Resistance: 10kR; Power Rating: 50mW; Width: 0.012inch; Weight: 6E-06oz; Voltage Rating (DC): 25V; Voltage Rating: 25V; Value: 10k, 1%; Termination: SMD/SMT; Technology: THICK FILM; Supplier Part Number 1: 311-10.0KMCT-ND; Supplier 1: Digi-Key; Stock 1: 17142315; Series: RC; RoHS Compliant: Yes; RoHS: Yes; Resistor Type: Thick Film; Resistance Tolerance: 1%; Reach SVHC Compliant: Yes; Radiation Hardening: No; Pricing 1: 1=0,10000, 10=0,03700, 100=0,01530, 1000=0,00685, 2500=0,00595, 5000=0,00491 (USD); Packaging: Tape and Reel; Package Quantity: 10000; Number of Terminations: 2; Number of Pins: 2; Min Operating Temperature: -55°C; Military Standard: Not; Max Power Dissipation: 50mW; Max Operating Temperature: 125°C; Length: 0.6mm; Lead Free: Lead Free; Height: 0.01inch; Features: Moisture Resistant; Depth: 0.3mm; Contact Plating: Tin,Matte; Construction: Rectangular; ComponentLink7URL: https://datasheet.ciiva.com/2467/pyu-r-int-thick-7-2467109.pdf?src-supplier=Digi-Key; ComponentLink7Description: https://datasheet.ciiva.com/2467/pyu-r-int-thick-7-2467109.pdf?src-supplier=Digi-Key; ComponentLink6URL: https://datasheet.ciiva.com/2467/pyu-r-int-thick-7-2467111.pdf?src-supplier=Digi-Key; ComponentLink6Description: https://datasheet.ciiva.com/2467/pyu-r-int-thick-7-2467111.pdf?src-supplier=Digi-Key; ComponentLink5URL: https://datasheet.ciiva.com/2467/pyu-r-int-thick-7-2467110.pdf?src-supplier=Digi-Key; ComponentLink5Description: https://datasheet.ciiva.com/2467/pyu-r-int-thick-7-2467110.pdf?src-supplier=Digi-Key; ComponentLink4URL: https://datasheet.ciiva.com/46391/1421516-46391339.pdf?src-supplier=Element14; ComponentLink4Description: https://datasheet.ciiva.com/46391/1421516-46391339.pdf?src-supplier=Element14; ComponentLink3URL: https://datasheet.ciiva.com/7660/1421516-7660807.pdf?src-supplier=Element14; ComponentLink3Description: https://datasheet.ciiva.com/7660/1421516-7660807.pdf?src-supplier=Element14; ComponentLink2URL: https://datasheet.ciiva.com/22661/1563626-22661319.pdf?src-supplier=Element14; ComponentLink2Description: https://datasheet.ciiva.com/22661/1563626-22661319.pdf?src-supplier=Element14; ComponentLink1URL: https://datasheet.ciiva.com/5924/1563626-5924912.pdf?src-supplier=Farnell; ComponentLink1Description: https://datasheet.ciiva.com/5924/1563626-5924912.pdf?src-supplier=Farnell; Component Kind: Standard; Case Code (Metric): 0603; Case Code (Imperial): 0201; Case/Package: 0201; Library Name: LimeFPGAboard_schLib.SchLib
  R112: Tolerance: 1%
R122-R129 = Res_0402: Part Description: 3.3 kOhms ±1% 0.05W, 1/20W Chip Resistor 0201 (0603 Metric) Moisture Resistant Thick Film; Manufacturer Part Number 1: RC0201FR-073K3L; Manufacturer: Yageo; Voltage Rating: 25V; Temperature Coefficient: 200ppm/°C; Resistance: 3.3kR; Power Rating: 50mW; Width: 0.3mm; Value: 3k3, 1%; Termination: SMD/SMT; Supplier Part Number 1: YAG2285CT-ND; Supplier 1: Digi-Key; Stock 1: 586100; Series: RC; RoHS Compliant: Yes; RoHS: Yes; Resistor Type: Thick Film; Resistance Tolerance: 1%; Pricing 1: 1=0,10000, 10=0,03700, 100=0,01500, 1000=0,00672, 2500=0,00583, 5000=0,00482 (USD); Packaging: Tape and Reel; Package Quantity: 10000; Number of Terminations: 2; Min Operating Temperature: -55°C; Military Standard: Not; Max Power Dissipation: 50mW; Max Operating Temperature: 125°C; Length: 0.024inch; Height: 0.01inch; Features: Moisture Resistant; Depth: 0.3mm; Construction: Rectangular; ComponentLink7URL: https://datasheet.ciiva.com/2467/pyu-r-int-thick-7-2467109.pdf?src-supplier=Digi-Key; ComponentLink7Description: https://datasheet.ciiva.com/2467/pyu-r-int-thick-7-2467109.pdf?src-supplier=Digi-Key; ComponentLink6URL: https://datasheet.ciiva.com/2467/pyu-r-int-thick-7-2467111.pdf?src-supplier=Digi-Key; ComponentLink6Description: https://datasheet.ciiva.com/2467/pyu-r-int-thick-7-2467111.pdf?src-supplier=Digi-Key; ComponentLink5URL: https://datasheet.ciiva.com/2467/pyu-r-int-thick-7-2467110.pdf?src-supplier=Digi-Key; ComponentLink5Description: https://datasheet.ciiva.com/2467/pyu-r-int-thick-7-2467110.pdf?src-supplier=Digi-Key; ComponentLink4URL: https://datasheet.ciiva.com/46391/1421516-46391339.pdf?src-supplier=Element14; ComponentLink4Description: https://datasheet.ciiva.com/46391/1421516-46391339.pdf?src-supplier=Element14; ComponentLink3URL: https://datasheet.ciiva.com/14985/pyu-r-int-thick-7-14985418.pdf?src-supplier=Digi-Key; ComponentLink3Description: https://datasheet.ciiva.com/14985/pyu-r-int-thick-7-14985418.pdf?src-supplier=Digi-Key; ComponentLink2URL: https://datasheet.ciiva.com/22698/1563626-22698524.pdf?src-supplier=Element14; ComponentLink2Description: https://datasheet.ciiva.com/22698/1563626-22698524.pdf?src-supplier=Element14; ComponentLink1URL: http://www.yageo.com/documents/recent/PYu-RC_Group_51_RoHS_L_7.pdf; ComponentLink1Description: http://www.yageo.com/documents/recent/PYu-RC_Group_51_RoHS_L_7.pdf; Component Kind: Standard; Case Code (Metric): 0603; Case Code (Imperial): 0201; Case/Package: 0201; Library Name: LimeFPGAboard_schLib.SchLib
  R122: Tolerance: 1%
R134 R137 R142 = Res_0402: Part Description: 330 Ohms ±1% 0.05W, 1/20W Chip Resistor 0201 (0603 Metric) Thick Film; Manufacturer Part Number 1: CRCW0201330RFKED; Manufacturer: Vishay Dale; Voltage Rating: 30V; Temperature Coefficient: 100ppm/°C; Power Rating: 50mW; Width: 0.3mm; Weight: 6E-06oz; Value: 330R, 1%; Supplier Part Number 1: 541-330AABCT-ND; Supplier 1: Digi-Key; Stock 1: 245078; Size Code: 0201; Series: CRCW; RoHS Compliant: Yes; RoHS: Yes; Resistor Type: Thick Film; Resistance: 330R; Radiation Hardening: No; Pricing 1: 1=0,27000, 10=0,23000, 100=0,08990, 1000=0,03762, 2500=0,03448, 5000=0,03135 (USD); Packaging: Tape and Reel; Package Quantity: 1; Number of Terminations: 2; Min Operating Temperature: -55°C; Military Standard: Not; Max Power Dissipation: 50mW; Max Operating Temperature: 155°C; Length: 0.6mm; Lead Free: Lead Free; Height: 0.011inch; Depth: 0.3mm; Contact Plating: Tin; Construction: Rectangular; ComponentLink5URL: https://datasheet.ciiva.com/16394/dcrcwe3-16394575.pdf?src-supplier=Future+Electronics; ComponentLink5Description: https://datasheet.ciiva.com/16394/dcrcwe3-16394575.pdf?src-supplier=Future+Electronics; ComponentLink4URL: https://datasheet.ciiva.com/2473/crcw0201e3-2473438.pdf?src-supplier=Digi-Key; ComponentLink4Description: https://datasheet.ciiva.com/2473/crcw0201e3-2473438.pdf?src-supplier=Digi-Key; ComponentLink3URL: https://datasheet.ciiva.com/2473/crcw0201e3-2473437.pdf?src-supplier=Digi-Key; ComponentLink3Description: https://datasheet.ciiva.com/2473/crcw0201e3-2473437.pdf?src-supplier=Digi-Key; ComponentLink2URL: https://datasheet.ciiva.com/2473/crcw0201e3-2473436.pdf?src-supplier=Digi-Key; ComponentLink2Description: https://datasheet.ciiva.com/2473/crcw0201e3-2473436.pdf?src-supplier=Digi-Key; ComponentLink1URL: https://datasheet.ciiva.com/8944/crcw0201e3-8944937.pdf?src-supplier=Avnet; ComponentLink1Description: https://datasheet.ciiva.com/8944/crcw0201e3-8944937.pdf?src-supplier=Avnet; Component Kind: Standard; Case Code (Metric): 0603; Case Code (Imperial): 0201; Case/Package: 0201; Library Name: LimeFPGAboard_schLib.SchLib
R135 R145 = Res_0402: Part Description: 100 kOhms ±1% 0.05W, 1/20W Chip Resistor 0201 (0603 Metric) Moisture Resistant Thick Film; Manufacturer Part Number 1: RC0201FR-07100KL; Manufacturer: Yageo; Voltage Rating (DC): 25V; Temperature Coefficient: 200ppm/°C; Resistance: 100kR; Power Rating: 50mW; Width: 0.012inch; Voltage Rating: 25V; Value: 100k, 1%; Termination: SMD/SMT; Supplier Part Number 1: 311-100KMCT-ND; Supplier 1: Digi-Key; Stock 1: 6001055; Size Code: 0201; Series: RC; RoHS Compliant: Yes; RoHS: Yes; Resistor Type: Thick Film; Resistance Tolerance: 1%; Reach SVHC Compliant: Yes; Pricing 1: 1=0,10000, 10=0,03700, 100=0,01500, 1000=0,00672, 2500=0,00583, 5000=0,00482 (USD); Packaging: Tape and Reel; Package Quantity: 10000; Number of Terminations: 2; Number of Pins: 2; Min Operating Temperature: -55°C; Military Standard: Not; Max Power Dissipation: 50mW; Max Operating Temperature: 125°C; Length: 0.024inch; Lead Free: Lead Free; Height: 0.01inch; Features: Moisture Resistant; Depth: 0.3mm; Contact Plating: Tin,Matte; Construction: Rectangular; ComponentLink12URL: https://datasheet.ciiva.com/26829/getdatasheetpartid-420441-26829850.pdf?src-supplier=Verical; ComponentLink12Description: https://datasheet.ciiva.com/26829/getdatasheetpartid-420441-26829850.pdf?src-supplier=Verical; ComponentLink11URL: https://datasheet.ciiva.com/2493/pyu-r-int-thick-7-2493769.pdf?src-supplier=Digi-Key; ComponentLink11Description: https://datasheet.ciiva.com/2493/pyu-r-int-thick-7-2493769.pdf?src-supplier=Digi-Key; ComponentLink10URL: https://datasheet.ciiva.com/2493/pyu-r-int-thick-7-2493771.pdf?src-supplier=Digi-Key; ComponentLink10Description: https://datasheet.ciiva.com/2493/pyu-r-int-thick-7-2493771.pdf?src-supplier=Digi-Key; ComponentLink9URL: https://datasheet.ciiva.com/2493/pyu-r-int-thick-7-2493770.pdf?src-supplier=Digi-Key; ComponentLink9Description: https://datasheet.ciiva.com/2493/pyu-r-int-thick-7-2493770.pdf?src-supplier=Digi-Key; ComponentLink8URL: https://datasheet.ciiva.com/46391/1421516-46391338.pdf?src-supplier=Element14; ComponentLink8Description: https://datasheet.ciiva.com/46391/1421516-46391338.pdf?src-supplier=Element14; ComponentLink7URL: https://datasheet.ciiva.com/22698/1563626-22698495.pdf?src-supplier=Element14; ComponentLink7Description: https://datasheet.ciiva.com/22698/1563626-22698495.pdf?src-supplier=Element14; ComponentLink6URL: https://datasheet.ciiva.com/7660/1421516-7660806.pdf?src-supplier=Element14; ComponentLink6Description: https://datasheet.ciiva.com/7660/1421516-7660806.pdf?src-supplier=Element14; ComponentLink5URL: https://datasheet.ciiva.com/8896/pyu-rc0201-51-rohs-l-8-8896174.pdf?src-supplier=Avnet; ComponentLink5Description: https://datasheet.ciiva.com/8896/pyu-rc0201-51-rohs-l-8-8896174.pdf?src-supplier=Avnet; ComponentLink4URL: https://datasheet.ciiva.com/29721/pyu-r-int-thick-7-29721195.pdf?src-supplier=Digi-Key; ComponentLink4Description: https://datasheet.ciiva.com/29721/pyu-r-int-thick-7-29721195.pdf?src-supplier=Digi-Key; ComponentLink3URL: https://datasheet.ciiva.com/29721/pyu-r-int-thick-7-29721196.pdf?src-supplier=Digi-Key; ComponentLink3Description: https://datasheet.ciiva.com/29721/pyu-r-int-thick-7-29721196.pdf?src-supplier=Digi-Key; ComponentLink2URL: https://datasheet.ciiva.com/22698/1563626-22698505.pdf?src-supplier=Element14; ComponentLink2Description: https://datasheet.ciiva.com/22698/1563626-22698505.pdf?src-supplier=Element14; ComponentLink1URL: https://datasheet.ciiva.com/7672/1563626-7672204.pdf?src-supplier=Element14; ComponentLink1Description: https://datasheet.ciiva.com/7672/1563626-7672204.pdf?src-supplier=Element14; Component Kind: Standard; Case Code (Metric): 0603; Case Code (Imperial): 0201; Case/Package: 0201; Library Name: LimeMicroAltiumLib_schLib.SchLib
X7 = 503480-0800_8PIN_FPC_CONN: Part Description: 8 Position FFC, FPC Connector Contacts, Top and Bottom 0.020" (0.50mm) Surface Mount, Right Angle; Manufacturer: Molex; Supplier Part Number 1: WM1388CT-ND; Supplier 1: Digi-Key; Stock 1: 119007; RoHS: Yes; Pricing 1: 1=0,92000, 10=0,76100, 100=0,63420, 1000=0,50736 (USD); Manufacturer Part Number 1: 503480-0800; ComponentLink5URL: https://datasheet.ciiva.com/26825/getdatasheetpartid-495673-26825585.pdf?src-supplier=Verical; ComponentLink5Description: https://datasheet.ciiva.com/26825/getdatasheetpartid-495673-26825585.pdf?src-supplier=Verical; ComponentLink4URL: https://datasheet.ciiva.com/5138/5034800800-ffc-fpc-connectors-179547-5138276.pdf?src-supplier=Mouser; ComponentLink4Description: https://datasheet.ciiva.com/5138/5034800800-ffc-fpc-connectors-179547-5138276.pdf?src-supplier=Mouser; ComponentLink3URL: https://datasheet.ciiva.com/10363/5034800800-ffc-fpc-connectors-10363985.pdf?src-supplier=Avnet; ComponentLink3Description: https://datasheet.ciiva.com/10363/5034800800-ffc-fpc-connectors-10363985.pdf?src-supplier=Avnet; ComponentLink2URL: https://datasheet.ciiva.com/5751/1631789-5751170.pdf?src-supplier=Farnell; ComponentLink2Description: https://datasheet.ciiva.com/5751/1631789-5751170.pdf?src-supplier=Farnell; ComponentLink1URL: https://datasheet.ciiva.com/671/5034800400-sd-671136.pdf?src-supplier=Digi-Key; ComponentLink1Description: https://datasheet.ciiva.com/671/5034800400-sd-671136.pdf?src-supplier=Digi-Key; Component Kind: Standard; Library Name: LimeMicroAltiumLib_schLib_r04.SchLib
X8 = PCIe_MiniCard_fingers: PCIe MiniCard full or half size; Library Name: LimeMicroAltiumLib_schLib_r04.SchLib
